# Altium schematic sheet NRF24L01-M.SchDoc
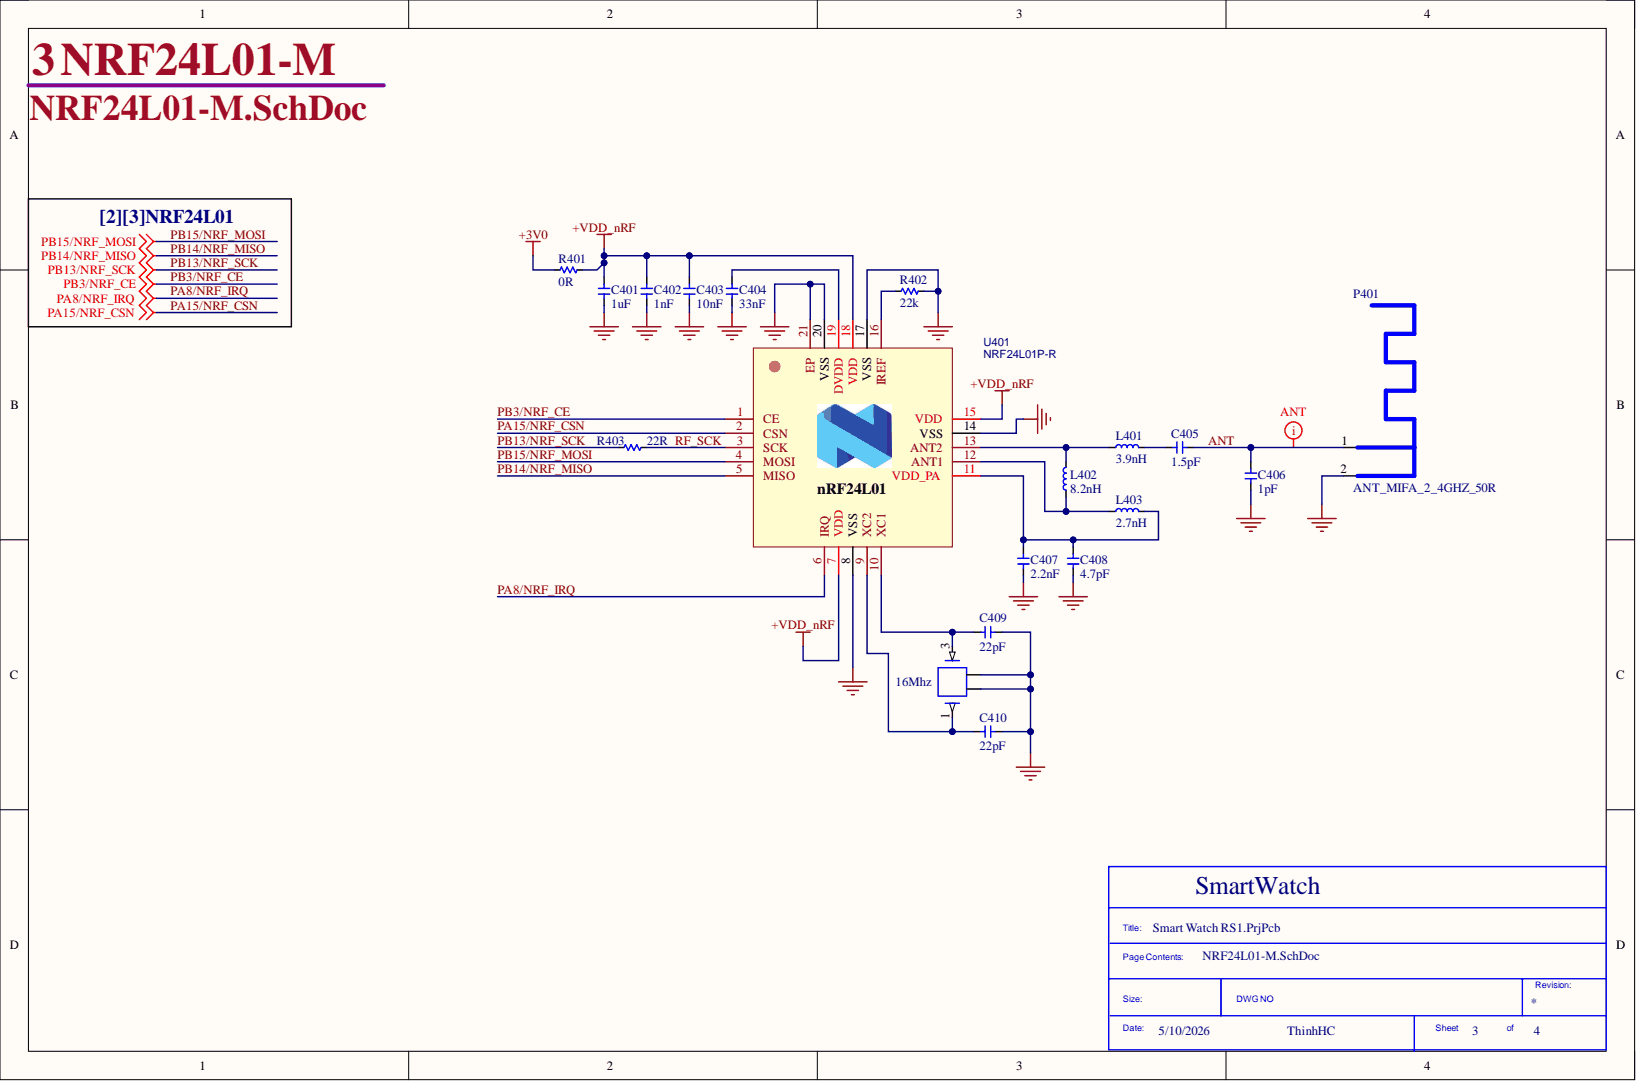
[diagram p0: NRF24L01-M.SchDoc]

## Parts
C401  1uF  [C_0402]
C402  1nF  [C_0402]
C403  10nF  [C_0402]
C404  33nF  [C_0402]
C405  1.5pF  [C_0402]
C406  1pF  [C_0402]
C407  2.2nF  [C_0402]
C408  4.7pF  [C_0402]
C409  22pF  [C_0402]
C410  22pF  [C_0402]
L401  3.9nH  [L_0402]
L402  8.2nH  [L_0402]
L403  2.7nH  [L_0402]
P401  ANT_MIFA_2_4GHZ_50R  [ANTENNA MIFA]  (no BOM)
R401  0R  [R_0402]
R402  22k  [R_0402]
R403  22R  [R_0402]
U401  NRF24L01P-R  [QFN-20_L4.0-W4.0-P0.50-BL-EP]
X401  16Mhz  [SMD-3225_4P]

## Nets
+3V0: R401.2
+VDD_nRF: C401.2 C402.2 C403.2 R401.1 U401.7(VDD) U401.15(VDD) U401.18(VDD)
GND: C401.1 C402.1 C403.1 C404.1 C406.1 C407.1 C408.1 C409.2 C410.2 P401.2 R402.2 U401.8(VSS) U401.14(VSS) U401.17(VSS) U401.20(VSS) U401.21(EP) X401.2 X401.4
ANT: C405.2 C406.2 P401.1
PA8/NRF_IRQ: U401.6(IRQ)
PA15/NRF_CSN: U401.2(CSN)
PB3/NRF_CE: U401.1(CE)
PB13/NRF_SCK: R403.2
PB14/NRF_MISO: U401.5(MISO)
PB15/NRF_MOSI: U401.4(MOSI)
RF_SCK: R403.1 U401.3(SCK)
NetC404_2: C404.2 U401.19(DVDD)
NetC405_1: C405.1 L401.2
NetC407_2: C407.2 C408.2 L403.2 U401.11(VDD_PA)
NetC409_1: C409.1 U401.10(XC1) X401.3
NetC410_1: C410.1 U401.9(XC2) X401.1
NetL401_1: L401.1 L402.2 U401.13(ANT2)
NetL402_1: L402.1 L403.1 U401.12(ANT1)
NetR402_1: R402.1 U401.16(IREF)

## Library data of the parts
C401 = 0402X105K100NT: 1uF 0402 10V X5R ±10% Multilayer Ceramic Capacitors MLCC - SMD/SMT ROHS; Manufacturer: FH (Guangdong Fenghua Advanced Tech); Assembly Type: SMT Assembly; Component Kind: Standard; ComponentLink1Description: Digikey Link; ComponentLink2Description: LCSC Link; ComponentLink2URL: https://jlcpcb.com/parts/componentSearch?searchTxt=10k%200603; LCSC Part Number: C157353; Library Name: RF Transmitter.SchLib; Manufacturer Part Number: 0402X105K100NT; Package: 0402
C402 = 0402B102K500NT: 1nF 0402 50V X7R ±10% Multilayer Ceramic Capacitors MLCC - SMD/SMT ROHS; Manufacturer: FH (Guangdong Fenghua Advanced Tech); Assembly Type: SMT Assembly; Component Kind: Standard; ComponentLink1Description: Digikey Link; ComponentLink2Description: LCSC Link; ComponentLink2URL: https://jlcpcb.com/parts/componentSearch?searchTxt=10k%200603; LCSC Part Number: C1523; Library Name: RF Transceiver.SchLib; Manufacturer Part Number: 0402B102K500NT; Package: 0402
C403 = 0402B103K500NT: 10nF 0402 50V X7R ±10% Multilayer Ceramic Capacitors MLCC - SMD/SMT ROHS; Manufacturer: FH (Guangdong Fenghua Advanced Tech); Assembly Type: SMT Assembly; Component Kind: Standard; ComponentLink1Description: Digikey Link; ComponentLink2Description: LCSC Link; ComponentLink2URL: https://jlcpcb.com/parts/componentSearch?searchTxt=10k%200603; LCSC Part Number: C1524; Library Name: RF Transmitter.SchLib; Manufacturer Part Number: 0402B103K500NT; Package: 0402
C404 = 0402B333K160NT: 33nF 0402 16V X7R ±10% 0402 Multilayer Ceramic Capacitors MLCC - SMD/SMT ROHS; Manufacturer: FH (Guangdong Fenghua Advanced Tech); Assembly Type: SMT Assembly; Component Kind: Standard; ComponentLink1Description: Digikey Link; ComponentLink2Description: LCSC Link; ComponentLink2URL: https://jlcpcb.com/parts/componentSearch?searchTxt=10k%200603; LCSC Part Number: C60425; Library Name: RF Transceiver.SchLib; Manufacturer Part Number: 0402B333K160NT; Package: 0402
C405 = 0402CG1R5C500NT: 1.5pF 0402 50V  C0G Multilayer Ceramic Capacitors MLCC - SMD/SMT ROHS; Manufacturer: FH (Guangdong Fenghua Advanced Tech); Assembly Type: SMT Assembly; Component Kind: Standard; ComponentLink1Description: Digikey Link; ComponentLink2Description: LCSC Link; ComponentLink2URL: https://jlcpcb.com/parts/componentSearch?searchTxt=10k%200603; LCSC Part Number: C1552; Library Name: RF Transceiver.SchLib; Manufacturer Part Number: 0402CG1R5C500NT; Package: 0402
C406 = 0402CG1R0C500NT: 1pF 0402 50V C0G Multilayer Ceramic Capacitors MLCC - SMD/SMT ROHS; Manufacturer: FH (Guangdong Fenghua Advanced Tech); Assembly Type: SMT Assembly; Component Kind: Standard; ComponentLink1Description: Digikey Link; ComponentLink2Description: LCSC Link; ComponentLink2URL: https://jlcpcb.com/parts/componentSearch?searchTxt=10k%200603; LCSC Part Number: C1550; Library Name: RF Transceiver.SchLib; Manufacturer Part Number: 0402CG1R0C500NT; Package: 0402
C407 = 0402B222K500NT: 2.2nF 0402 50V X7R ±10% Multilayer Ceramic Capacitors MLCC - SMD/SMT ROHS; Manufacturer: FH (Guangdong Fenghua Advanced Tech); Assembly Type: SMT Assembly; Component Kind: Standard; ComponentLink1Description: Digikey Link; ComponentLink2Description: LCSC Link; ComponentLink2URL: https://jlcpcb.com/parts/componentSearch?searchTxt=10k%200603; LCSC Part Number: C1531; Library Name: RF Transceiver.SchLib; Manufacturer Part Number: 0402B222K500NT; Package: 0402
C408 = 0402CG4R7C500NT: 4.7pF 0402 50V C0G Multilayer Ceramic Capacitors MLCC - SMD/SMT ROHS; Manufacturer: FH (Guangdong Fenghua Advanced Tech); Assembly Type: SMT Assembly; Component Kind: Standard; ComponentLink1Description: Digikey Link; ComponentLink2Description: LCSC Link; ComponentLink2URL: https://jlcpcb.com/parts/componentSearch?searchTxt=10k%200603; LCSC Part Number: C1569; Library Name: RF Transceiver.SchLib; Manufacturer Part Number: 0402CG4R7C500NT; Package: 0402
C409 C410 = 0402CG220J500NT: 22pF 0402 50V C0G ±5% Multilayer Ceramic Capacitors MLCC - SMD/SMT ROHS; Manufacturer: FH (Guangdong Fenghua Advanced Tech); Assembly Type: SMT Assembly; Component Kind: Standard; ComponentLink1Description: Digikey Link; ComponentLink2Description: LCSC Link; ComponentLink2URL: https://jlcpcb.com/parts/componentSearch?searchTxt=10k%200603; LCSC Part Number: C1555; Library Name: RF Transceiver.SchLib; Manufacturer Part Number: 0402CG220J500NT; Package: 0402
L401 = FHW0402UC3N9JST: 3.9nH 840mA ±5% 66mΩ 0402 Inductors (SMD) ROHS; Manufacturer: FH (Guangdong Fenghua Advanced Tech); Assembly Type: SMT Assembly; Component Kind: Standard; LCSC Part Number: C317939; Library Name: RF Transceiver.SchLib; Manufacturer Part Number: FHW0402UC3N9JST; Package: 0402
L402 = FHW0402UC8N2JST: 8.2nH 680mA ±5% 100mΩ 0402 Inductors (SMD) ROHS; Manufacturer: FH (Guangdong Fenghua Advanced Tech); Assembly Type: SMT Assembly; Component Kind: Standard; LCSC Part Number: C317946; Library Name: RF Transceiver.SchLib; Manufacturer Part Number: FHW0402UC8N2JST; Package: 0402
L403 = FHW0402UC2N7KST: 2.7nH 640mA ±10% 120mΩ 0402 Inductors (SMD) ROHS; Manufacturer: FH (Guangdong Fenghua Advanced Tech); Assembly Type: SMT Assembly; Component Kind: Standard; LCSC Part Number: C317935; Library Name: RF Transceiver.SchLib; Manufacturer Part Number: FHW0402UC2N7KST; Package: 0402
P401 = ANT_MIFA_2_4GHZ_50R: MeanderedInverted F 2.4GHz ISM PCB Antenna 50 Ohm; Library Name: RF Transceiver.SchLib
R401 = 0402WGF0000TCE: 0Ω 0402 62.5mW Thick Film Resistors 50V ±800ppm/℃ ±1%Chip Resistor - Surface Mount ROHS; Manufacturer: Uniroyal Elec; Assembly Type: SMT Assembly; Component Kind: Standard; LCSC Part Number: C17168; Library Name: RF Transceiver.SchLib; Manufacturer Part Number: 0402WGF0000TCE; Package: 0402
R402 = 0402WGF2202TCE: 22kΩ 0402 62.5mW Thick Film Resistors 50V ±100ppm/℃ ±1% Chip Resistor - Surface Mount ROHS; Manufacturer: Uniroyal Elec; Assembly Type: SMT Assembly; Component Kind: Standard; LCSC Part Number: C25768; Library Name: RF Transceiver.SchLib; Manufacturer Part Number: 0402WGF2202TCE; Package: 0402
R403 = 0402WGF220JTCE: 22Ω 0402 62.5mW Thick Film Resistors 50V ±1% ±100ppm/℃ Chip Resistor - Surface Mount ROHS; Manufacturer: Uniroyal Elec; Assembly Type: SMT Assembly; Component Kind: Standard; LCSC Part Number: C25092; Library Name: RF Transceiver.SchLib; Manufacturer Part Number: 0402WGF220JTCE; Package: 0402
U401 = NRF24L01P-R: NRF24L01P-R SPI QFN-20_4x4x05P RF Transceiver ICs ROHS; Manufacturer: NORDIC; Assembly Type: SMT Assembly; Component Kind: Standard; LCSC Part Number: C8791; Library Name: RF Transceiver.SchLib; Manufacturer Part Number: NRF24L01P-R; Package: QFN-20_4x4x05P
X401 = X322516MLB4SI: 16MHz SMD Crystal Resonator 9pF ±10ppm ±30ppm -40℃~+85℃ SMD3225-4P Crystals ROHS; Manufacturer: Yangxing Tech; Assembly Type: SMT Assembly; Component Kind: Standard; LCSC Part Number: C13738; Library Name: RF Transceiver.SchLib; Manufacturer Part Number: X322516MLB4SI; Package: SMD-3225_4P
